# Altium schematic sheet peripherals.SchDoc
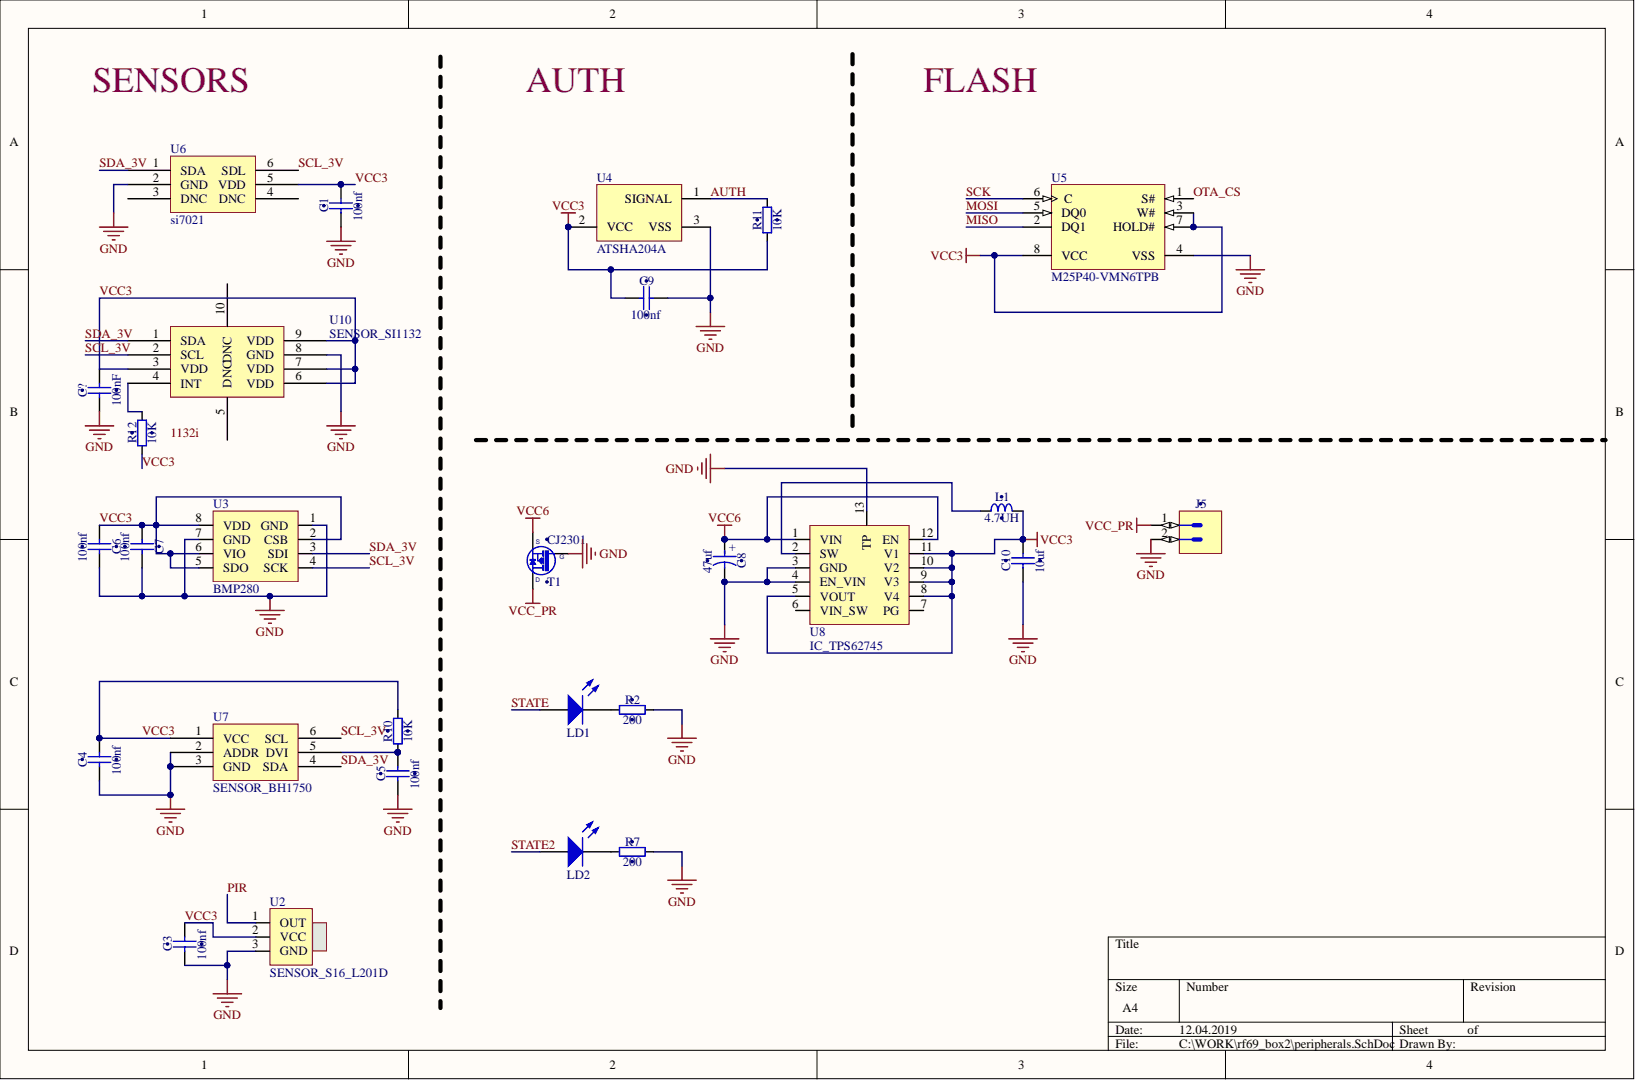
[diagram p0: peripherals.SchDoc]

## Parts
C1  100nf  [CAP_0402]
C3  100nf  [CAP_0402]
C4  100nf  [CAP_0402]
C5  100nf  [CAP_0402]
C6  100nf  [CAP_0402]  (no BOM)
C7  100nf  [CAP_0402]  (no BOM)
C8  47uf  [CAP_3216]
C9  100nf  [CAP_0402]
C10  10uf  [CAP_0805]
J5  2P_MALE_JST1.25  [JST_1.25_2P_MALE]  (value not drawn)
L1  4.7UH  [INDC2520X10N_1008]
LD1  0603 red  [0603_A]  (value not drawn)
LD2  0603 green  [0603_A]  (value not drawn)
R2  200  [RES_0402]
R7  200  [RES_0402]
R10  10K  [RES_0402]
R11  10K  [RES_0402]
T1  CJ2301  [SOT95P240X110-3N]
U2  SENSOR_S16_L201D  [S16-L201D]
U3  BMP280  [BMP280]
U4  ATSHA204A  [SOT95P240X110-3N]
U5  M25P40-VMN6TPB  [SOIC127P600X175-8N]
U6  si7021  [DFN6D_N]
U7  SENSOR_BH1750  [BH1750]
U8  IC_TPS62745  [tps62745]

## Nets
GND: C1.1 C3.1 C4.1 C5.1 C6.2 C7.2 C8.2 C9.2 C10.1 J5.2 R2.2 R7.2 T1.1 U2.3 U3.1(GND) U3.7(GND) U4.3 U5.4(VSS) U6.2(GND) U7.2(ADDR) U7.3(GND) U8.3(GND) U8.4(EN_VIN) U8.13(TP)
VCC6: C8.1 T1.2 U8.1(VIN) U8.12(EN)
VCC_PR: J5.1 T1.3
AUTH: R11.2 U4.1
MISO: U5.2(DQ1)
MOSI: U5.5(DQ0)
OTA_CS: U5.1(S#)
PIR: U2.1
SCK: U5.6(C)
SCL_3V: U3.4(SCK) U6.6(SDL) U7.6(SCL)
SDA_3V: U3.3(SDI) U6.1(SDA) U7.4(SDA)
STATE: LD1.2
STATE2: LD2.2
VCC3: C1.2 C3.2 C4.2 C6.1 C7.1 C9.1 C10.2 L1.2 R10.2 R11.1 U2.2 U3.2(CSB) U3.5(SDO) U3.6(VIO) U3.8(VDD) U4.2 U5.3(W#) U5.7(HOLD#) U5.8(VCC) U6.5(VDD) U7.1(VCC) U8.5(VOUT) U8.8(V4) U8.9(V3) U8.10(V2) U8.11(V1)
NetC5_2: C5.2 R10.1 U7.5(DVI)
NetL1_1: L1.1 U8.2(SW)
NetLD1_1: LD1.1 R2.1
NetLD2_1: LD2.1 R7.1
1132I:
N00041:

Not connected: U6 3(DNC) 4(DNC); U8 6(VIN_SW) 7(PG)

## Library data of the parts
C1 C3-C7 C9 = C0402_100NF: Non-polarised capacitor; Database Table Name: CAP; GoodsBriefName: RC_C_0402_100NF_CC0402KRX7R7BB104_1PCS; GoodsID: 59197; Код: 1; Case: 0402; Part Number: C0402_100NF; Pin Count: 2; Value: 100nf; Library Name: MIPS_COMPONENTS_LIB.DbLib
  C1 C3-C5 C9: Component Kind: Standard
C8 = CT_47UF_6.3V_3216: Polarised capacitor; Database Table Name: CAP; GoodsBriefName: RC_C_1206_47UF_TANTALUM; GoodsID: 52840; Код: 11; Case: 3216; Component Kind: Standard; Part Number: CT_47UF_6.3V_3216; Pin Count: 2; Value: 47uf; Поле1: ?; Library Name: MIPS_COMPONENTS_LIB.DbLib
C10 = C0805_10UF: Non-polarised capacitor; Database Table Name: CAP; Код: 22; Case: 0805; Component Kind: Standard; Part Number: C0805_10UF; Pin Count: 2; Value: 10uf; Library Name: MIPS_COMPONENTS_LIB.DbLib
J5 = CONN_2P_MALE_JST1.25: Connector 2 Male; Database Table Name: CONN; GoodsBriefName: RC_CN_MICRO_JST_1-25MM_2PIN_MALE; GoodsID: 51419; Код: 23; Component Kind: Standard; Part Number: CONN_2P_MALE_JST1.25; Pin Count: 2; Value: 2P_MALE_JST1.25; Library Name: MIPS_COMPONENTS_LIB.DbLib
L1 = IND_4.7UH_2520: Inductor; Database Table Name: IND; GoodsBriefName: RC_INDUCTOR_2520_4-7UH; GoodsID: 59491; Код: 4; Case: 2520; Component Kind: Standard; Part Number: IND_4.7UH_2520; Pin Count: 2; Value: 4.7UH; Library Name: MIPS_COMPONENTS_LIB.DbLib
LD1 = LED_0603_RED: SMD mono-color Chip LED, Red; Database Table Name: MISC; GoodsBriefName: RC_LED_SMD_0603_RED_LSR-0603; GoodsID: 51415; Код: 9; Case: 0603; Component Kind: Standard; Part Number: LED_0603_RED; Pin Count: 2; Value: 0603_RED; Library Name: MIPS_COMPONENTS_LIB.DbLib
LD2 = LED_0603_GREEN: SMD mono-color Chip LED, Red; Database Table Name: MISC; GoodsBriefName: RC_LED_SMD_0603_YELLOW-GREEN; GoodsID: 59403; Код: 10; Case: 0603; Component Kind: Standard; Part Number: LED_0603_GREEN; Pin Count: 2; Value: 0603_GREEN; Library Name: MIPS_COMPONENTS_LIB.DbLib
R2 R7 = R0402_200_1%: Resistor; Database Table Name: res; Код: 22; Case: 0402; Component Kind: Standard; Part Number: R0402_200_1%; Pin Count: 2; Value: 200; Library Name: MIPS_COMPONENTS_LIB.DbLib
R10 R11 = R0402_10K_1%: Resistor; Database Table Name: res; GoodsBriefName: RC_R_0402_10KOM_1PERCENT_1PCS; GoodsID: 59404; Код: 1; Case: 0402; Component Kind: Standard; Part Number: R0402_10K_1%; Pin Count: 2; Value: 10K; Library Name: MIPS_COMPONENTS_LIB.DbLib
T1 = TR_CJ2301: P-CHANNEL MOSFET WITH DIODE; Database Table Name: TR; GoodsBriefName: RC_TR_CJ2301_SOT23-3; GoodsID: 52844; Код: 2; Case: SOT23; Component Kind: Standard; Part Number: TR_CJ2301; Pin Count: 3; Value: CJ2301; Library Name: MIPS_COMPONENTS_LIB.DbLib
U2 = SENSOR_S16_L201D: Database Table Name: SENSORS; GoodsBriefName: RC_IC_PIR_S16-L201D; GoodsID: 58181; Код: 2; Component Kind: Standard; Part Number: SENSOR_S16_L201D; Pin Count: 3; Value: S16_L201D; Library Name: MIPS_COMPONENTS_LIB.DbLib
U4 = IC_ATSHA204A: CryptoAuthentication™ Host Security Chip, Industrial (-40 to 85 degC), 3-Pin SOT, Tape and Reel, Pb-Free; Database Table Name: IC; GoodsBriefName: RC_IC_ATSHA204A-STUCZ-T_SOT23; GoodsID: 52067; Код: 20; Case: SOP8; Component Kind: Standard; Part Number: IC_ATSHA204A; Pin Count: 3; Value: ATSHA204A; Library Name: MIPS_COMPONENTS_LIB.DbLib
U5 = IC_M25P40-VMN-8: 4 Mb (x1) Serial NOR Flash Memory, 75 MHz, 2.3 to 3.6 V, 8-pin SO8 Narrow (MN), Tape & Reel; Manufacturer: Micron; Part Family: M25PÂ; Database Table Name: IC; Density: 4MbÂ; GoodsBriefName: RC_IC_M25P40-VMN6TPB; GoodsID: 52086; Speed: 75 MHzÂ; Voltage: 2.3V-3.6VÂ; Код: 21; Applications: EmbeddedÂ; Case: WSON12; Component Kind: Standard; ComponentLink1Description: Manufacturer URL; ComponentLink1URL: http://www.micron.com/; ComponentLink2Description: Datasheet; ComponentLink2URL: http://www.micron.com/~/media/Documents/Products/Data%20Sheet/NOR%20Flash/Serial%20NOR/M25P/M25P40.pdf; ComponentLink3Description: Package Specification; ComponentLink3URL: http://www.micron.com/~/media/Documents/Products/Data%20Sheet/NOR%20Flash/Serial%20NOR/M25P/M25P05A.pdf; DatasheetVersion: Rev. Y; Mounting Technology: Surface Mount; Package: SO8 NarrowÂ; PackageDescription: 8-Pin SO Narrow, 3.9 X 4.9 mm, Pitch 1.27 mm; PackageReference: MICT-MN-8; PackageVersion: Rev 11; Part Number: IC_M25P40-VMN-8; PartNumber: M25P40-VMN6TPB; Part Status: ProductionÂ; Pin Count: 8; RoHS: YesÂ; Type: Data StorageÂ; Value: M25P40-VMN-8; Width: x1Â; Library Name: MIPS_COMPONENTS_LIB.DbLib
U6 = SENSOR_SI7020: Database Table Name: SENSORS; GoodsBriefName: RC_IC_SI7021-A20-GM1R_DFN6; GoodsID: 55498; Value: si7020; Код: 4; Component Kind: Standard; Part Number: SENSOR_SI7020; Pin Count: 6; Library Name: MIPS_COMPONENTS_LIB.DbLib
U7 = SENSOR_BH1750: Database Table Name: SENSORS; GoodsBriefName: ARDUINO_SENSOR_LIGHT_INTENSITY_IC_BH1750FVI_WSOF6; GoodsID: 53450; Value: BH1750; Код: 1; Component Kind: Standard; Part Number: SENSOR_BH1750; Pin Count: 6; Library Name: MIPS_COMPONENTS_LIB.DbLib
U8 = IC_TPS62745: Database Table Name: IC; GoodsBriefName: RC_IC_TPS62745DSSR_WSON12; GoodsID: 58166; Код: 19; Case: WSON12; Component Kind: Standard; Part Number: IC_TPS62745; Pin Count: 12; Value: TPS62745; Library Name: MIPS_COMPONENTS_LIB.DbLib
U3 = BMP280: Component Kind: Standard; Library Name: rf69.SchLib
